# Altium schematic sheet USB_SWITCH.SchDoc(USB_SWITCH)
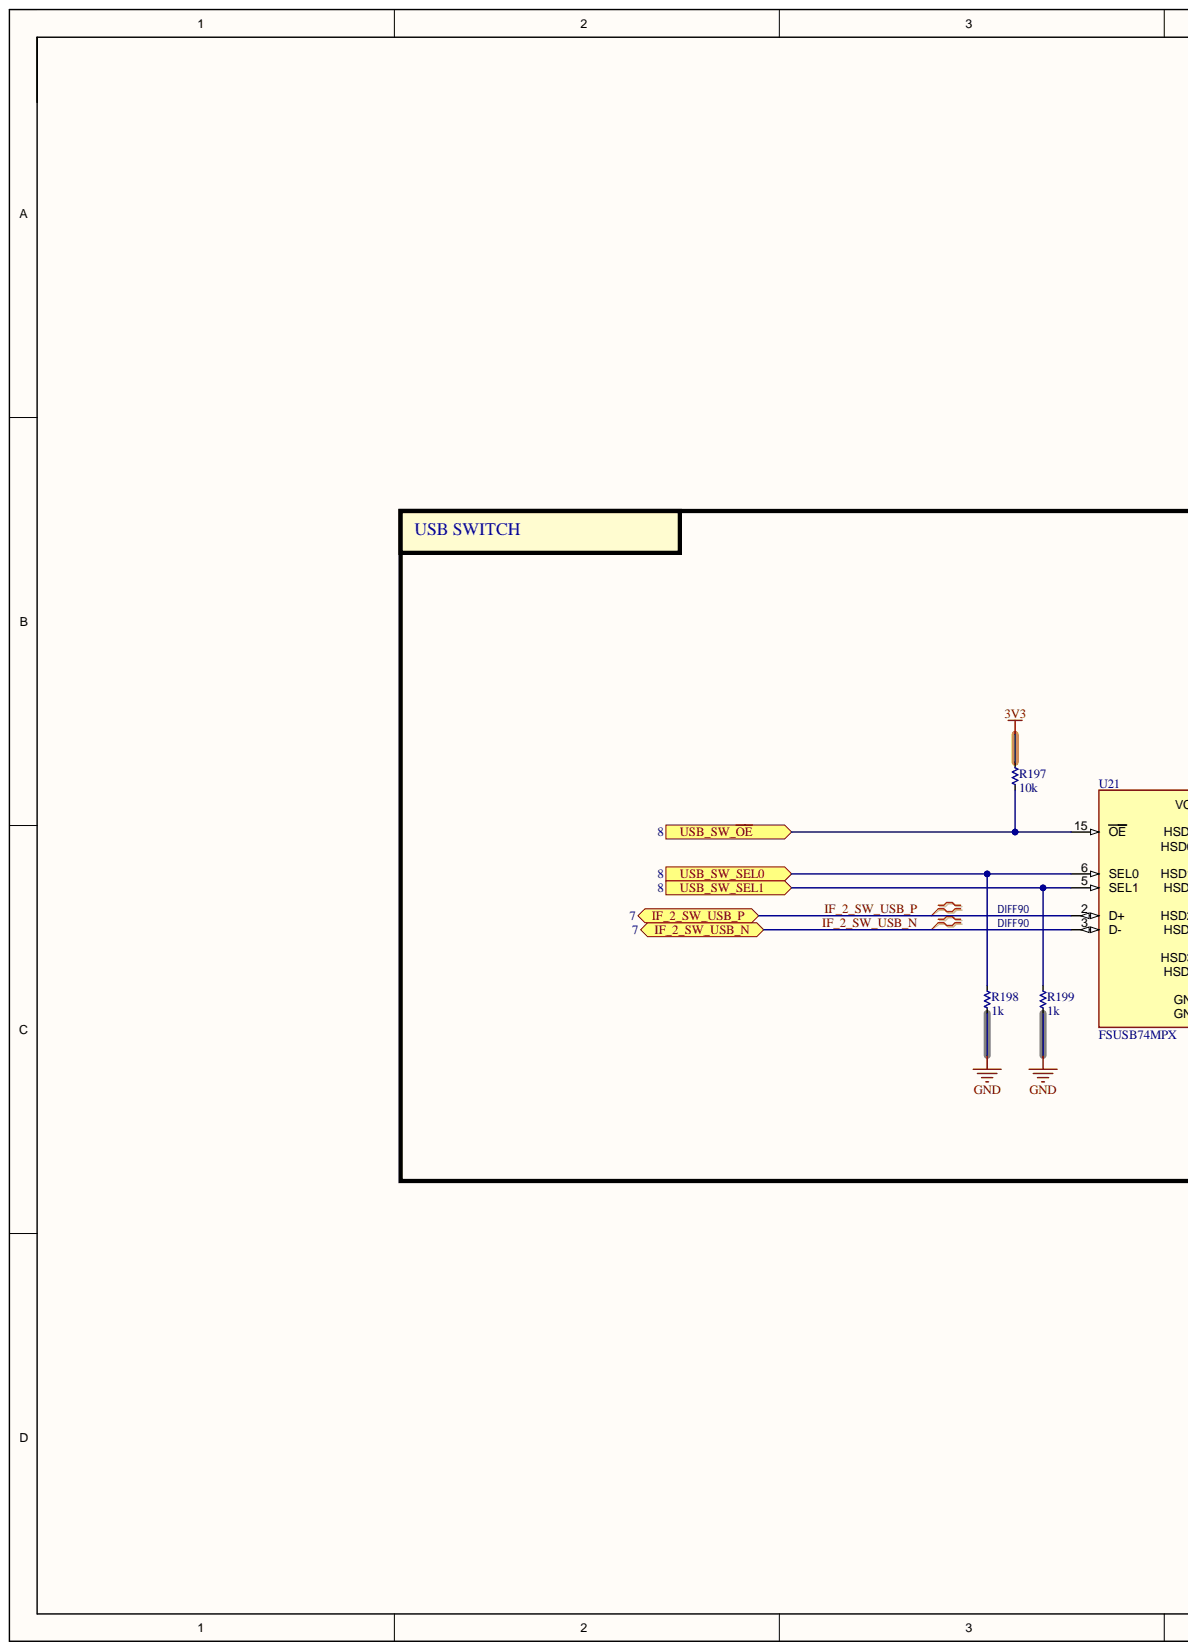
[diagram p0: USB_SWITCH.SchDoc, left]
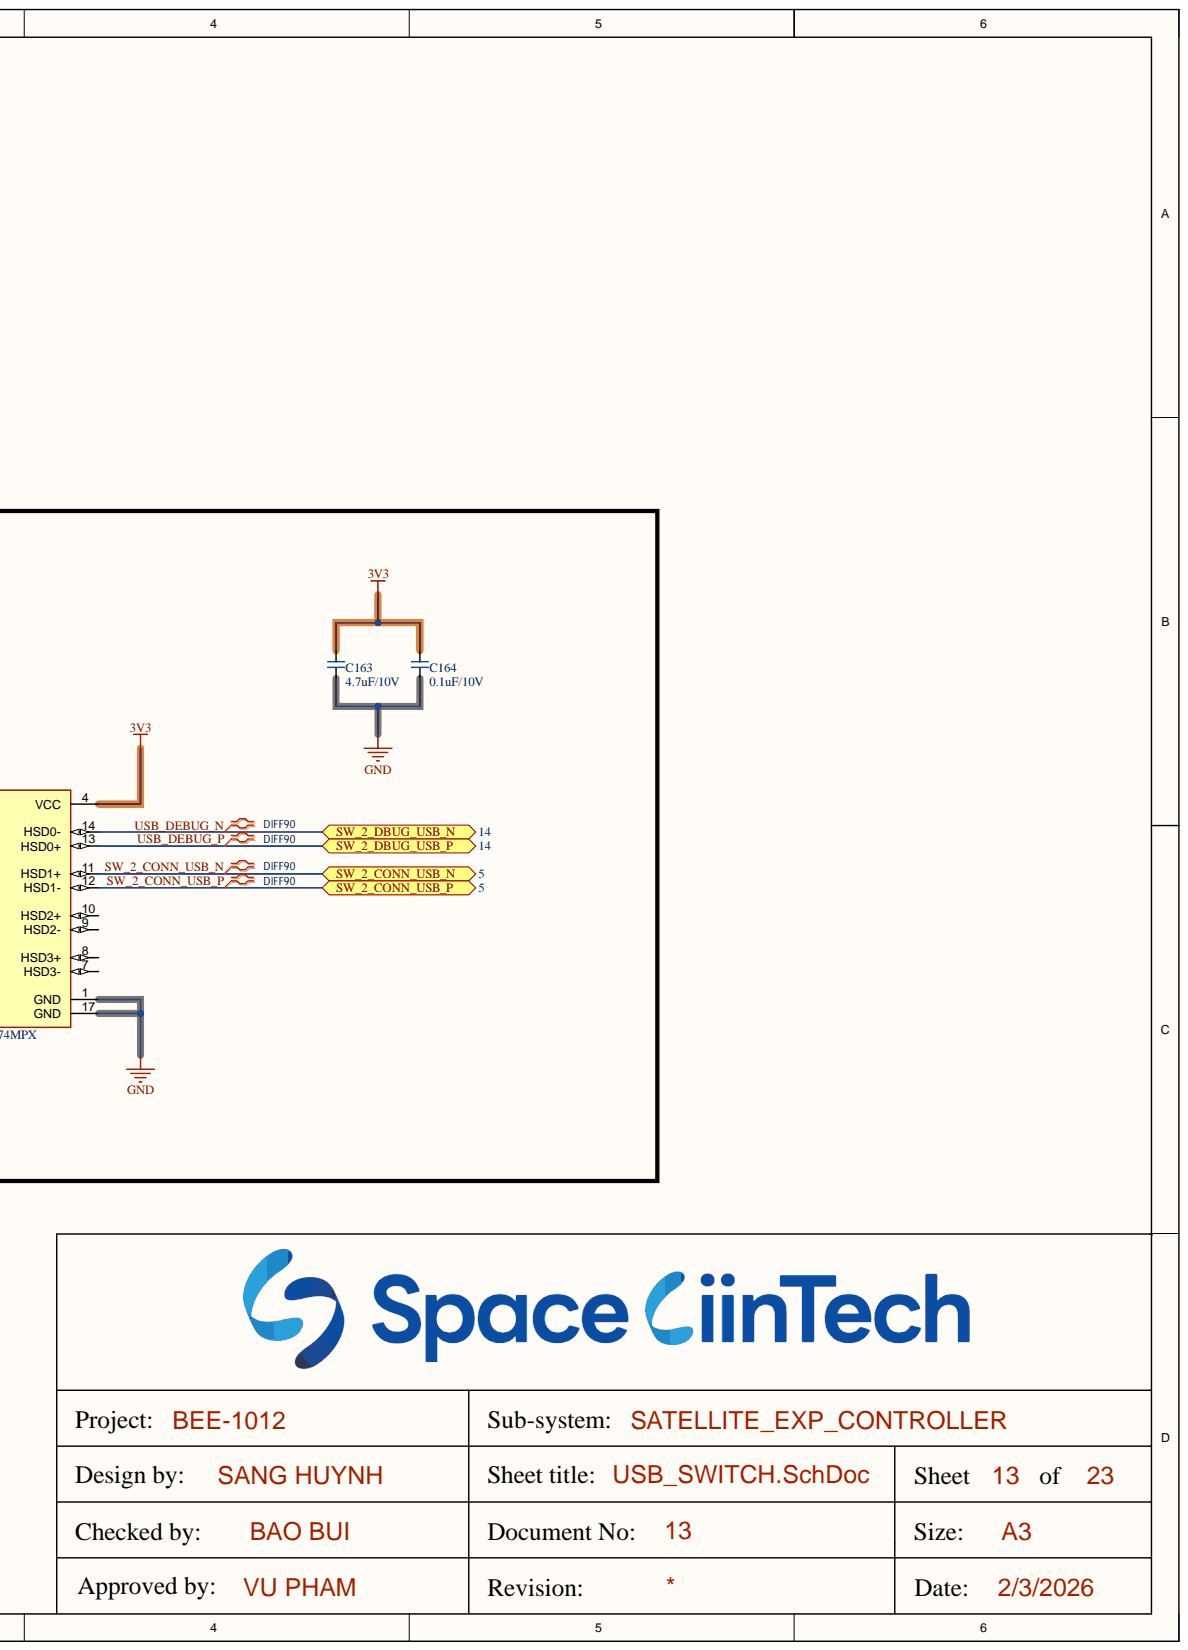
[diagram p1: USB_SWITCH.SchDoc, right]

## Parts
C163  4.7uF/10V  [CAP_C_1005X50_L]
C164  0.1uF/10V  [CAP_C_1005X50_L]
R197  10k  [RES_C_1005x30_L]
R198  1k  [RES_C_1005x30_L]
R199  1k  [RES_C_1005x30_L]
U21  FSUSB74MPX  [QFN50P300X300X80-17N]

## Ports
IF_2_SW_USB_N, IF_2_SW_USB_P, SW_2_CONN_USB_N, SW_2_CONN_USB_P, SW_2_DBUG_USB_N, SW_2_DBUG_USB_P, USB_SW_SEL0, USB_SW_SEL1, USB_SW_~{OE}

## Nets
3V3: C163.2 C164.2 R197.2 U21.4(VCC)
GND: C163.1 C164.1 R198.1 R199.1 U21.1(GND) U21.17(GND)
IF_2_SW_USB_N: U21.3(D-) ; port IF_2_SW_USB_N
IF_2_SW_USB_P: U21.2(D+) ; port IF_2_SW_USB_P
SW_2_CONN_USB_N: U21.11(HSD1+) ; port SW_2_CONN_USB_N
SW_2_CONN_USB_P: U21.12(HSD1-) ; port SW_2_CONN_USB_P
USB_DEBUG_N: U21.14(HSD0-) ; port SW_2_DBUG_USB_N
USB_DEBUG_P: U21.13(HSD0+) ; port SW_2_DBUG_USB_P
USB_SW_~{OE}: R197.1 U21.15(OE) ; port USB_SW_~{OE}
USB_SW_SEL0: R198.2 U21.6(SEL0) ; port USB_SW_SEL0
USB_SW_SEL1: R199.2 U21.5(SEL1) ; port USB_SW_SEL1

Not connected: U21 7(HSD3-) 8(HSD3+) 9(HSD2-) 10(HSD2+)

## Library data of the parts
C163 C164 = _CAP_C_2: CAP CHIP; Component Kind: Standard; Library Name: IFBschelib.SchLib
R197-R199 = _RES_B_2: RES; Component Kind: Standard; Library Name: IFBschelib.SchLib
U21 = IC_USB_SW_FSUSB74MPX: USB Switch IC 1 Channel 16-MLP (3x3); MF: ON Semiconductor; Check_prices: https://www.snapeda.com/parts/FSUSB74MPX/Onsemi/view-part/?ref=eda; Component Kind: Standard; MP: FSUSB74MPX; Package: WQFN-16 ON Semiconductor; SnapEDA_Link: https://www.snapeda.com/parts/FSUSB74MPX/Onsemi/view-part/?ref=snap; Library Name: IFBschelib.SchLib
